# Altium schematic sheet TVR_Rev2_Ignition.SchDoc(TVR_Rev2_Ignition)
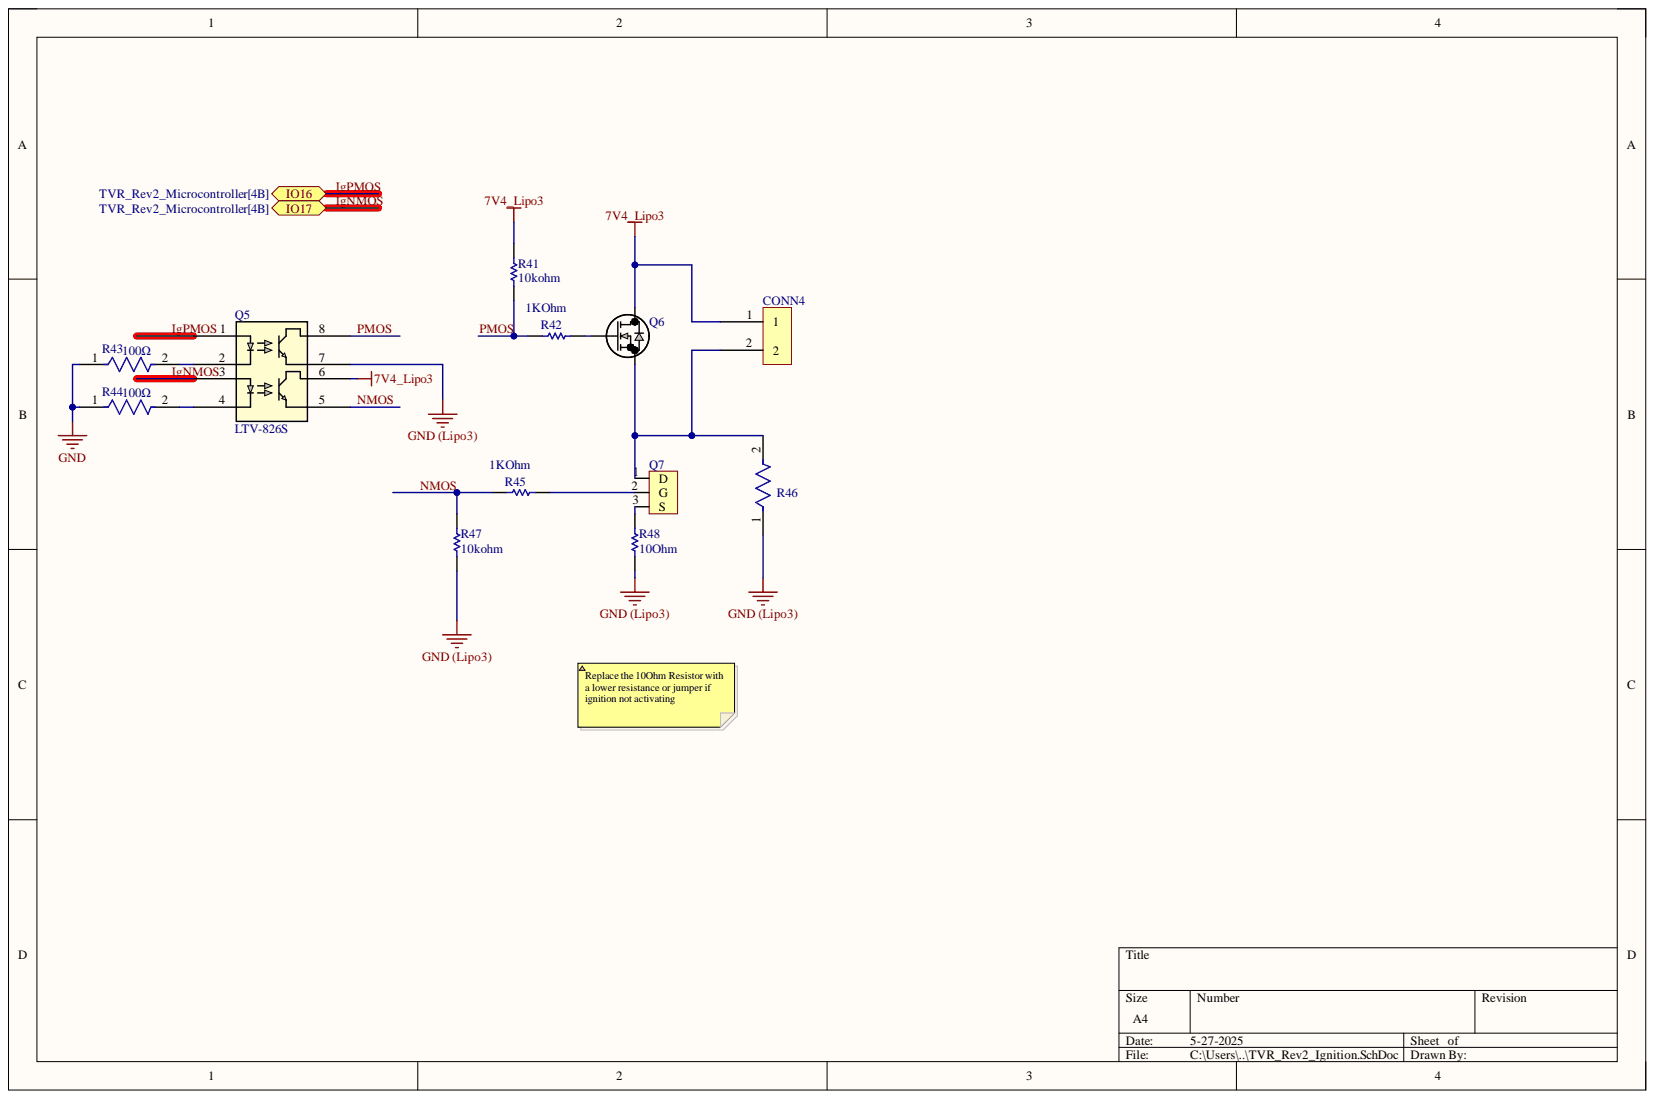
[diagram p0: TVR_Rev2_Ignition.SchDoc]

## Parts
CONN4  691137710002  [2PIN_TERMINAL]  (value not drawn)
Q5  LTV-826S  [LTV-826S_LTO]
Q6  AOD4189  [TO-252_D-PAK_AOS]  (value not drawn)
Q7  DMNH6021SK3Q-13  [TO252(DPAK)]  (value not drawn)
R41  Resistance: 10kohm  [RESC2013X60X35ML10T20]  (hidden comment: RC0805JR-0710KL)
R42  Resistance: 1KOhm  [RESC2013X60X35ML10T20]  (hidden comment: RC0805FR-071KL)
R43  Resistance: 100Ω  [FP-RC0603-0_55-MFG]  (hidden comment: RC0603FR-07100RL)
R44  Resistance: 100Ω  [FP-RC0603-0_55-MFG]  (hidden comment: RC0603FR-07100RL)
R45  Resistance: 1KOhm  [RESC2013X60X35ML10T20]  (hidden comment: RC0805FR-071KL)
R46  RC0805FR-07100KL  [FP-RC0805-0_6-IPC_C]  (value not drawn)
R47  Resistance: 10kohm  [RESC2013X60X35ML10T20]  (hidden comment: RC0805JR-0710KL)
R48  Resistance: 10Ohm  [RESC2013X60X35ML10T20]  (hidden comment: RC0805FR-0710RL)

## Ports
IO16, IO17

## Nets
7V4_Lipo3: CONN4.1 Q5.6 Q6.3 R41.2
GND: R43.1 R44.1
GND (Lipo3): Q5.7 R46.1 R47.2 R48.1
IgNMOS: Q5.3 ; port IO17
IgPMOS: Q5.1 ; port IO16
NMOS: Q5.5 R45.1 R47.1
PMOS: Q5.8 R41.1 R42.1
NetCONN4_2: CONN4.2 Q6.2 Q7.1 R46.2
NetQ5_2: Q5.2 R43.2
NetQ5_4: Q5.4 R44.2
NetQ6_1: Q6.1 R42.2
NetQ7_2: Q7.2 R45.2
NetQ7_3: Q7.3 R48.2

## Library data of the parts
CONN4 = 2PIN_TERMINAL: Component Kind: Standard; Library Name: Schlib2.SchLib
Q5 = LTV-826S: No Description Available; Mfr_Name: LITE-ON; Component Kind: Standard; RefDes: RefDes; Type: Type; Library Name: TVR.SchLib
Q6 = AOD4189: P Channel MOSFET 40V 40A; Mfr_Name: Alpha and Omega Semiconductor; Component Kind: Standard; Library Name: TVR.SchLib
Q7 = DMNH6021SK3Q-13: N-Channel MOSFET, 60V 50A SMD with drain pad; Component Kind: Standard; Library Name: TVR.SchLib
R41 R47 = CMP-2000-05025-1: Chip Resistor, 10 KOhm, +/- 5%, 0.125 W, -55 to 155 degC, 0805 (2012 Metric), RoHS, Tape and Reel; Manufacturer: Yageo; Power Rating: 250mW; Product Range: RCSeries; Resistor Case Style: 0805[2012Metric]; Resistor Element Type: ThickFilm; SVHC: ToBeAdvised; Temperature Coefficient: ±100ppm/degC; Voltage Rating: 150V; Automotive Qualification Standard: -; Component Kind: Standard; Datasheet URL: http://www.yageo.ru/pdf/RC0805.pdf; Datasheet Version: REV. 2, 09/2004; Library Name: Altium Content Vault; Manufacturer URL: http://www.yageo.com/; MSL: MSL1-Unlimited; Package Description: 2-Pin Surface Mounted Device, Body 2 x 1.25 mm; Package Reference: 805; Packaging: CutTape; Resistance Tolerance: ±5%
R42 R45 = CMP-2100-03671-1: Chip Resistor, 1 KOhm, +/- 1%, 125 mW, -55 to 155 degC, 0805 (2012 Metric), RoHS, Tape and Reel; Manufacturer: Yageo; Max Power Dissipation: 125mW; Temperature Coefficient: 100ppm/degreesC; Voltage Rating: 150V; Case Code (Imperial): 0805; Case Code (Metric): 2012; Case/Package: 0805; Component Kind: Standard; Composition: ThickFilm; Datasheet URL: http://www.yageo.com/NewPortal/yageodocoutput?fileName=/pdf/R-Chip/PYu-RC_Group_51_RoHS_L_5.pdf; Datasheet Version: Rev. 5, 10/2016; Depth: 1.25mm; Height: 0.024inch; Lead Free: LeadFree; Length: 0.079inch; Library Name: Altium Content Vault; Manufacturer URL: http://www.yageo.com/; Max Operating Temperature: 155degC; Military Standard: Not; Min Operating Temperature: -55degC; Number of Pins: 2; Number of Terminations: 2; Package Description: 2-Pin Surface Mounted Device, Body 2 x 1.25 mm; Package Quantity: 1; Package Reference: 0805; Packaging: TapeandReel; Power Rating: 125mW; REACH SVHC: Unknown; RoHSCompliant: true; Termination: SMD/SMT; Tolerance: 1%; Voltage Rating (DC): 150V; Width: 0.049inch
R43 R44 = CMP-2100-03663-2: NexarPartId: 55402879; Power Rating: 100mW; Temperature Coefficient: 100ppm/°C; Tolerance: 1%; Voltage Rating: 50V; Case Code (Imperial): 0603; Case Code (Metric): 0603; Case/Package: 0603; Component Kind: Standard; Composition: Thick Film; Depth: 800µm; Height: 450µm; Lead Free: Lead Free; Length: 1.6mm; Library Name: Altium Content Vault; Max Operating Temperature: 155°C; Military Standard: Not; Min Operating Temperature: -55°C; Number of Pins: 2; Packaging: Tape & Reel; REACH SVHC: Unknown; RoHS: Compliant; Schedule B: 8533210045, 8533210045; Termination: SMD/SMT; Voltage Rating (DC): 50V; Width: 800µm
R46 = CMP-2100-03668-5: RES 100K OHM 1% 1/8W 0805; Max Power Dissipation: 125mW; Resistance: 100kR; Temperature Coefficient: 100ppm/°C; Voltage Rating: 150V; Case Code (Imperial): 0805; Case Code (Metric): 2012; Case/Package: 0805; Component Kind: Standard; Construction: Rectangular; Depth: 1.25mm; Features: Moisture Resistant; Height: 0.024inch; Lead Free: Lead Free; Lead Pitch: 0.4mm; Length: 0.079inch; Library Name: Altium Content Vault; Max Operating Temperature: 155°C; Military Standard: Not; Min Operating Temperature: -55°C; Number of Pins: 2; Number of Terminations: 2; Package Quantity: 1; Packaging: Tape and Reel; Power Rating: 125mW; Resistance Tolerance: 1%; Resistor Type: Thick Film; RoHS Compliant: Yes; Series: RC; Size Code: 0805; Technology: THICK FILM; Termination: SMD/SMT; Tolerance: 1%; Voltage Rating (DC): 150V; Width: 0.049inch
R48 = CMP-2100-03670-1: Chip Resistor, 10 Ohm, +/- 1%, 0.125 W, -55 to 155 degC, 0805 (2012 Metric), RoHS, Tape and Reel; Manufacturer: Yageo; Max Power Dissipation: 125mW; Temperature Coefficient: 200ppm/degreesC; Voltage Rating: 150V; Case Code (Imperial): 0805; Case Code (Metric): 2012; Case/Package: 0805; Component Kind: Standard; Composition: ThickFilm; Datasheet URL: http://www.yageo.com/NewPortal/yageodocoutput?fileName=/pdf/R-Chip/PYu-RC_Group_51_RoHS_L_5.pdf; Datasheet Version: Rev. 5, 10/2016; Depth: 1.25mm; Height: 0.5mm; Lead Free: LeadFree; Length: 2mm; Library Name: Altium Content Vault; Manufacturer URL: http://www.yageo.com/; Max Operating Temperature: 155degC; Min Operating Temperature: -55degC; Number of Pins: 2; Number of Terminations: 2; Package Description: 2-Pin Surface Mounted Device, Body 2 x 1.25 mm; Package Quantity: 1; Package Reference: 0805; Packaging: TapeandReel; Power Rating: 125mW; REACH SVHC: NoSVHC; RoHSCompliant: true; Termination: SMD/SMT; Tolerance: 1%; Voltage Rating (DC): 150V; Width: 1.25mm
